# Altium schematic sheet Sheet2.SchDoc(Sheet2)
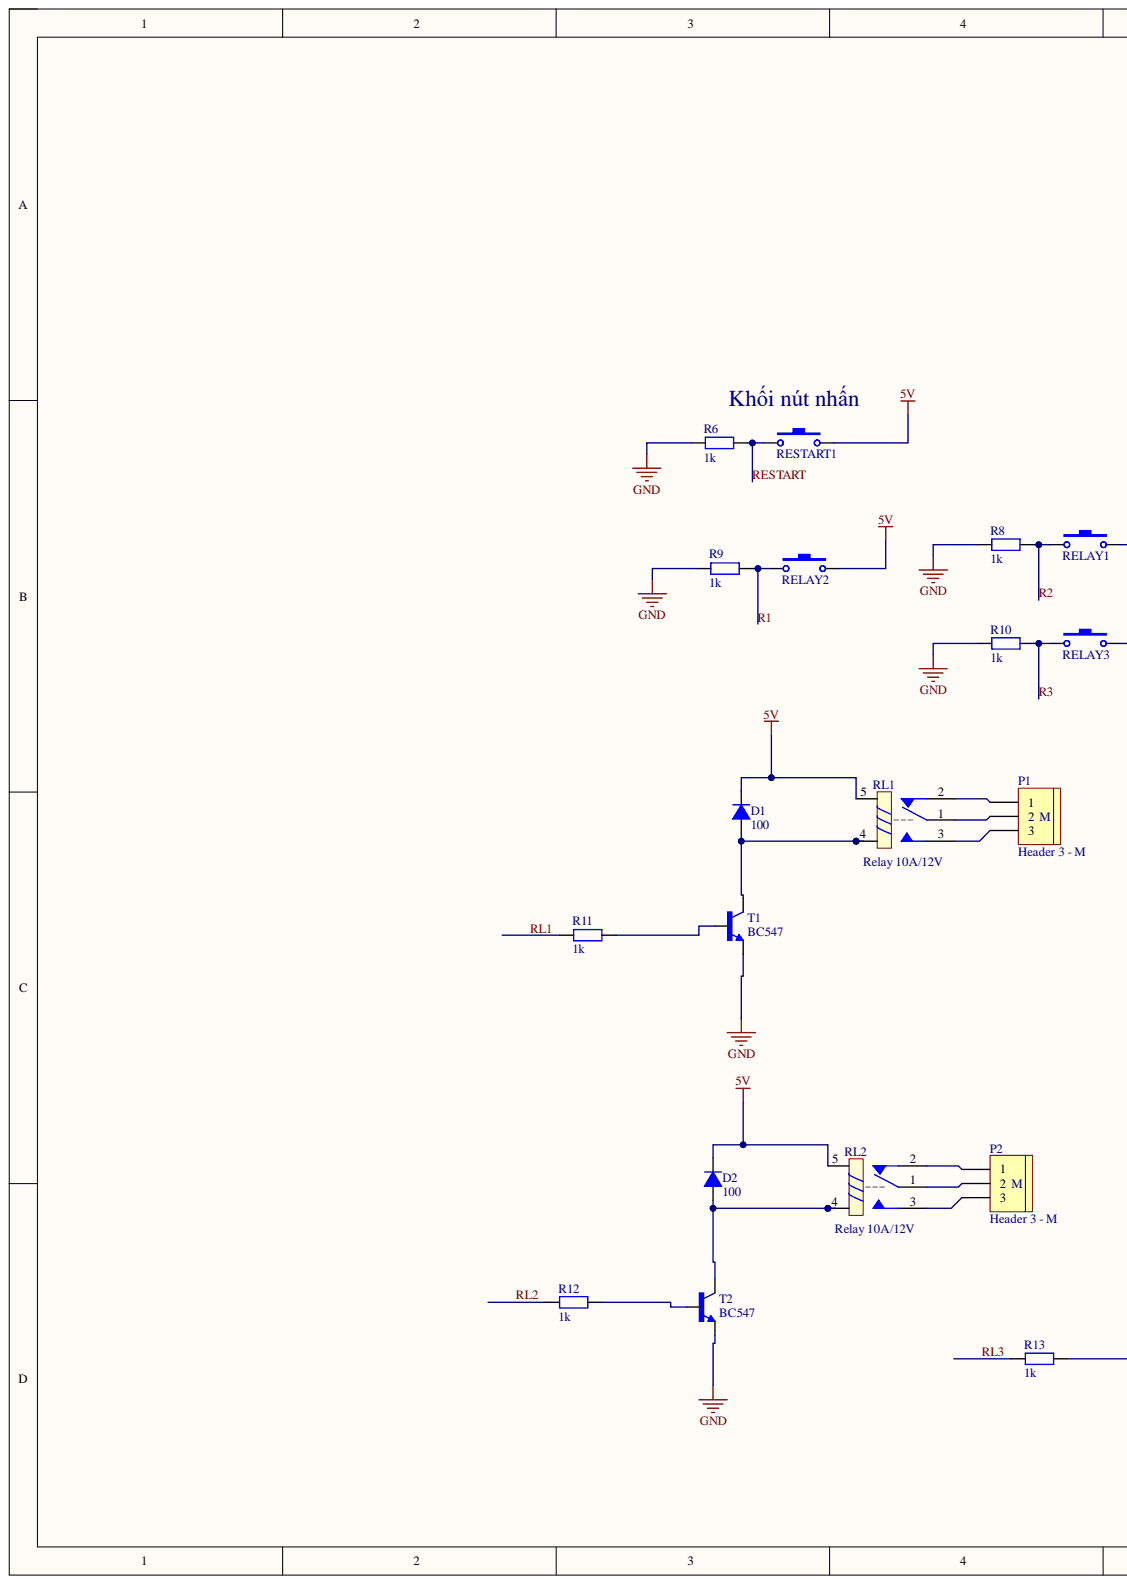
[diagram p0: Sheet2.SchDoc, left]
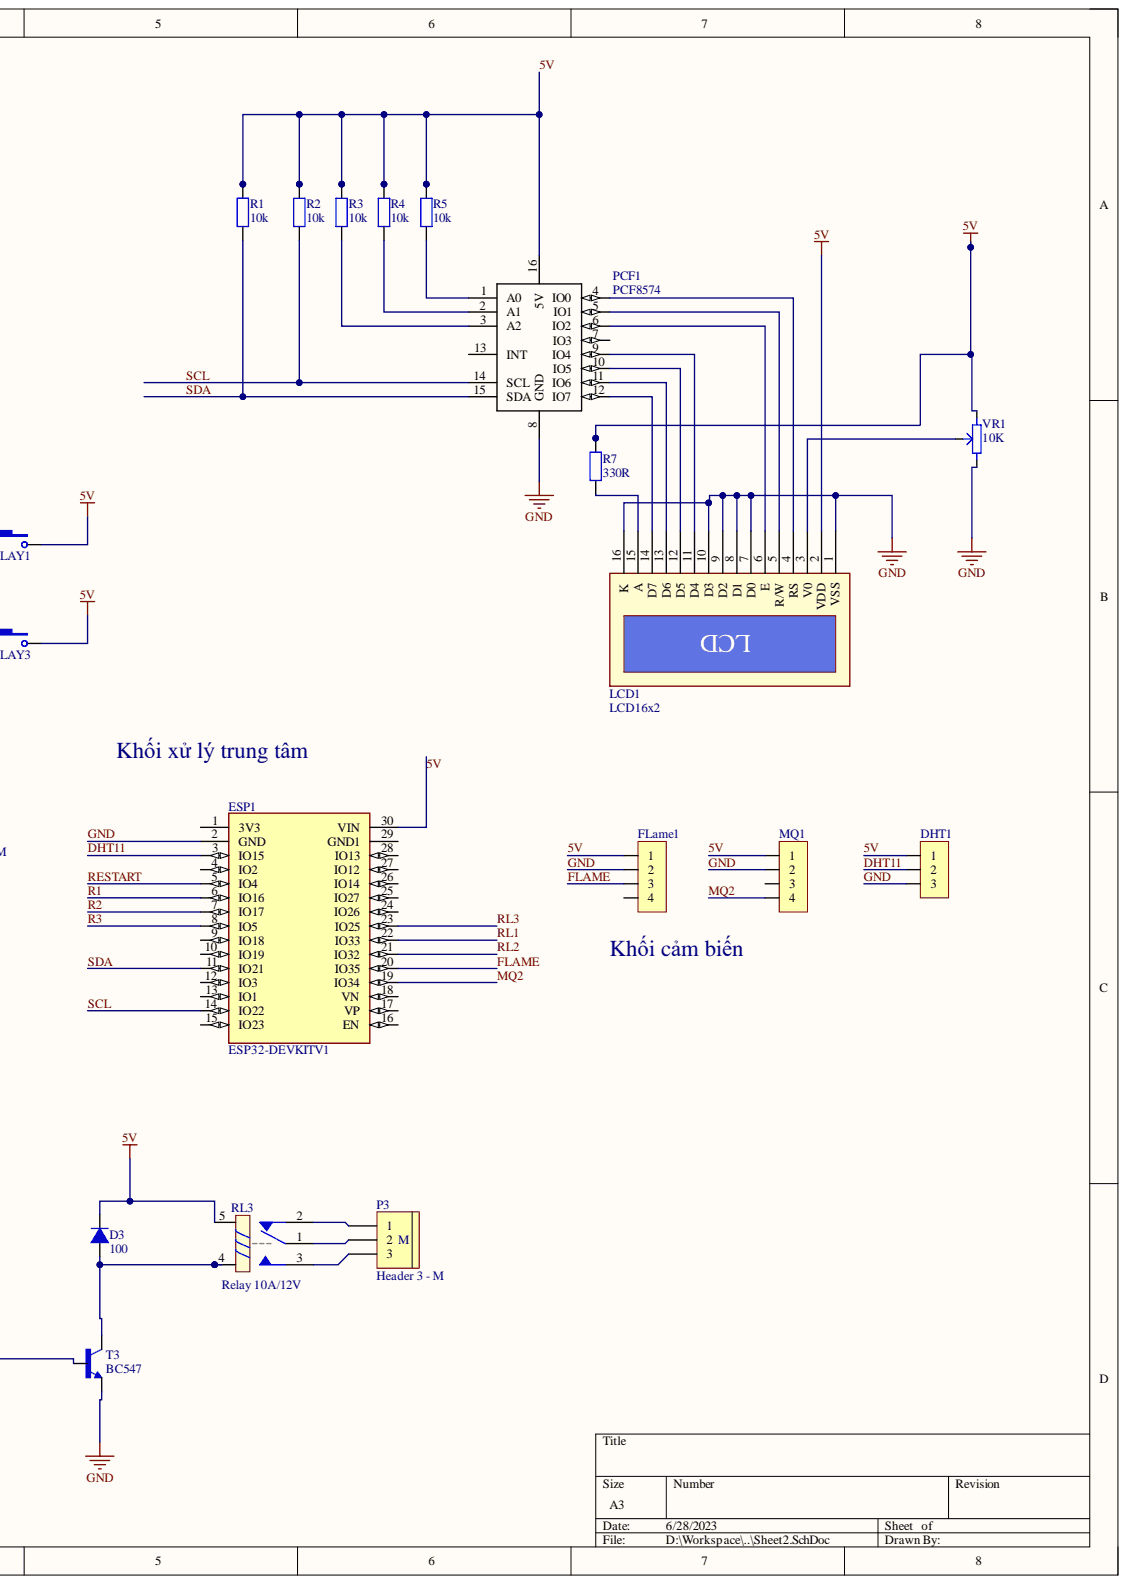
[diagram p1: Sheet2.SchDoc, right]

## Parts
D1  100  [DIODE 1A]
D2  100  [DIODE 1A]
D3  100  [DIODE 1A]
DHT1  JP 1x3 2.54  [JP 1x3 CC]  (value not drawn)
ESP1  ESP32DEVKITV1  [ESP32-DEVKITV1_3D]
FLame1  JP 1x4 2.54  [JP 1x4 CT]  (value not drawn)
LCD1  LCD16x2  [LCD16X2]
MQ1  JP 1x4 2.54  [JP 1x4 CT]  (value not drawn)
P1  Header 3 - M  [Medium - Header 3]
P2  Header 3 - M  [Medium - Header 3]
P3  Header 3 - M  [Medium - Header 3]
PCF1  PCF8574  [DIP16]
R1  10k  [tro smd 0805]
R2  10k  [tro smd 0805]
R3  10k  [tro smd 0805]
R4  10k  [tro smd 0805]
R5  10k  [tro smd 0805]
R6  1k  [RES-1/4W]
R7  330R  [Tro SMD 0805]
R8  1k  [RES-1/4W]
R9  1k  [RES-1/4W]
R10  1k  [RES-1/4W]
R11  1k  [RES-1/4W]
R12  1k  [RES-1/4W]
R13  1k  [RES-1/4W]
RELAY1  SW  [BUTTON  ROUND]  (value not drawn)
RELAY2  SW  [BUTTON  ROUND]  (value not drawn)
RELAY3  SW  [BUTTON  ROUND]  (value not drawn)
RESTART1  SW  [BUTTON  ROUND]  (value not drawn)
RL1  Relay 10A/12V  [RL_SPDT_10A]
RL2  Relay 10A/12V  [RL_SPDT_10A]
RL3  Relay 10A/12V  [RL_SPDT_10A]
T1  BC547  [TO92]
T2  BC547  [TO92]
T3  BC547  [TO92]
VR1  10K  [Bien Tro Tam Giac Dung]

## Nets
5V: D1.2 D2.2 D3.2 DHT1.1 ESP1.30(VIN) FLame1.1 LCD1.2(VDD) MQ1.1 PCF1.16(5V) R1.2 R2.2 R3.2 R4.2 R5.2 R7.2 RELAY1.2 RELAY2.2 RELAY3.2 RESTART1.2 RL1.5 RL2.5 RL3.5 VR1.3
DHT11: DHT1.2 ESP1.3(IO15)
FLAME: ESP1.20(IO35) FLame1.3
GND: DHT1.3 ESP1.2(GND) FLame1.2 LCD1.1(VSS) LCD1.7(D0) LCD1.8(D1) LCD1.9(D2) LCD1.10(D3) LCD1.16(K) MQ1.2 PCF1.8(GND) R6.1 R8.1 R9.1 R10.1 T1.1 T2.1 T3.1 VR1.1
MQ2: ESP1.19(IO34) MQ1.4
R1: ESP1.6(IO16) R9.2 RELAY2.1
R2: ESP1.7(IO17) R8.2 RELAY1.1
R3: ESP1.8(IO5) R10.2 RELAY3.1
RESTART: ESP1.5(IO4) R6.2 RESTART1.1
RL1: ESP1.22(IO33) R11.1
RL2: ESP1.21(IO32) R12.1
RL3: ESP1.23(IO25) R13.1
SCL: ESP1.14(IO22) PCF1.14(SCL) R2.1
SDA: ESP1.11(IO21) PCF1.15(SDA) R1.1
NetD1_1: D1.1 RL1.4 T1.3
NetD2_1: D2.1 RL2.4 T2.3
NetD3_1: D3.1 RL3.4 T3.3
NetLCD1_3: LCD1.3(V0) VR1.2
NetLCD1_4: LCD1.4(RS) PCF1.4(IO0)
NetLCD1_5: LCD1.5(R/W) PCF1.5(IO1)
NetLCD1_6: LCD1.6(E) PCF1.6(IO2)
NetLCD1_11: LCD1.11(D4) PCF1.9(IO4)
NetLCD1_12: LCD1.12(D5) PCF1.10(IO5)
NetLCD1_13: LCD1.13(D6) PCF1.11(IO6)
NetLCD1_14: LCD1.14(D7) PCF1.12(IO7)
NetLCD1_15: LCD1.15(A) R7.1
NetP1_1: P1.1 RL1.2
NetP1_2: P1.2 RL1.1
NetP1_3: P1.3 RL1.3
NetP2_1: P2.1 RL2.2
NetP2_2: P2.2 RL2.1
NetP2_3: P2.3 RL2.3
NetP3_1: P3.1 RL3.2
NetP3_2: P3.2 RL3.1
NetP3_3: P3.3 RL3.3
NetPCF1_1: PCF1.1(A0) R5.1
NetPCF1_2: PCF1.2(A1) R4.1
NetPCF1_3: PCF1.3(A2) R3.1
NetR11_2: R11.2 T1.2
NetR12_2: R12.2 T2.2
NetR13_2: R13.2 T3.2

Not connected: ESP1 1(3V3) 4(IO2) 9(IO18) 10(IO19) 12(IO3) 13(IO1) 15(IO23) 16(EN) 17(VP) 18(VN) 24(IO26) 25(IO27) 26(IO14) 27(IO12) 28(IO13) 29(GND1); FLame1 4; MQ1 3; PCF1 7(IO3) 13(INT)

## Library data of the parts
D1-D3 = Diode 1N4007: Diode 1N4007 cắm; Don_Vi: Con; Ma_Hang: MH001063; Ten_Linh_Kien: Diode 1N4007 1A (DIP); Chung_Loai: Cắm; Component Kind: Standard; Library Name: DIODE.SchLib
DHT1 = JP 1x3 2.54 Cai Cong: Cắm; Don_Vi: Con; Ma_Hang: MH001265; Ten_Linh_Kien: Jump Đơn Cái 2.54MM 1x40P Cong; Chung_Loai: Cắm; Component Kind: Standard; Library Name: Jump.SchLib
ESP1 = ESP32DEVKITV1: Dual core, Wi-Fi: 2.4 GHz up to 150 Mbits/s,BLE (Bluetooth Low Energy) and legacy Bluetooth, 32 bits, Up to 240 MHz; MF: Do it; Availability: Unavailable; Check_prices: https://www.snapeda.com/parts/ESP32%20DEVKIT%20V1/Do%20it/view-part/5659245/?ref=eda; Component Kind: Standard; MP: ESP32 DEVKIT V1; Package: Package; Price: None; SnapEDA_Link: https://www.snapeda.com/parts/ESP32%20DEVKIT%20V1/Do%20it/view-part/5659245/?ref=snap; Library Name: SchlibBruno.SchLib
FLame1 MQ1 = JP 1x4 2.54 Cai Thang: Cắm; Don_Vi: Con; Ma_Hang: MH004006; Ten_Linh_Kien: Jump Đơn Cái 2.54MM 1x20P Thẳng; Chung_Loai: Cắm; Component Kind: Standard; Library Name: Jump.SchLib
LCD1 = LCD Text: jump hàn; Component Kind: Standard; Library Name: KhanhBK_SCH_Library_2.SchLib
P1-P3 = Header 3 - M: Header, 3-Pin, Medium; Component Kind: Standard; LatestRevisionDate: 17-Jul-2002; LatestRevisionNote: Re-released for DXP Platform.; Signal Integrity: Connector; Library Name: KhanhBK_SCH_Library_2.SchLib; Publisher: Altium Limited
PCF1 = PCF8574: I2C PORT EXPANDER dán; Manufacturer: NXP Semiconductors; Manufacturer Part Number: PCF8574T/3,512; Frequency - Clock: 100KHz; Includes: POR; Interface: I²C; Interrupt Output: Yes; Number of I /O: 8; Voltage - Supply: 2.5 V ~ 6 V; Category: Integrated Circuits (ICs); Component Kind: Standard; Operating Temperature: -40°C ~ 85°C; Package / Case: 16-SOIC (7.5mm Width); Packaging: Tube; RoHS: RoHS Compliant; Supplier: Digi-Key; Supplier 1: Digi-Key; Supplier Part Number: 568-1076-5-ND; Supplier Part Number 1: 568-1076-5-ND; Library Name: KhanhBK_SCH_Library_2.SchLib
R1-R3 R5 = Tro 0805 1% 10k: Dán; Don_Vi: Con; Ma_Hang: MH005473; So_Chan: 2; Ten_Linh_Kien: Trở 0805 1% 10k; Chung_Loai: Dán; Component Kind: Standard; Library Name: Dien Tro.SchLib
R4 = Tro 0805 5% 10k: Dán; Don_Vi: Con; Ma_Hang: MH005239; So_Chan: 2; Ten_Linh_Kien: Trở 0805 5% 10k; Chung_Loai: Dán; Component Kind: Standard; Library Name: Dien Tro.SchLib
R6 R8-R13 = R: Resistor R1/4W; Component Kind: Standard; Library Name: Resistor.SchLib
R7 = Tro 0805 5% 330R: Dán; Don_Vi: Con; Ma_Hang: MH005337; So_Chan: 2; Ten_Linh_Kien: Trở 0805 5% 330R; Chung_Loai: Dán; Component Kind: Standard; Library Name: Dien Tro.SchLib
RELAY1-RELAY3 RESTART1 = SW-Button: Switch-Button; Component Kind: Standard; LatestRevisionDate: 17-Jul-2002; LatestRevisionNote: Re-released for DXP Platform.; Library Name: BUTTON-SWITCH.SchLib; PackageReference: SPST-2; Published: 24-Mar-1999; Publisher: Altium Limited
RL1-RL3 = Relay 1: Relay SPDT,10A - 12VDC 5 Pin; Value: Relay SPDT, 10A, 12V; Component Kind: Standard; Library Name: RELAY.SchLib
T1-T3 = BC547: Bipolar (BJT) Transistor NPN 45V 100mA 300MHz 625mW Through Hole TO-92 (TO-226); MF: ON; Availability: In Stock; Check_prices: https://www.snapeda.com/parts/BC547/Onsemi/view-part/?ref=eda; Component Kind: Standard; Library Name: BC547.IntLib; MP: BC547; Package: E-PKG AXIAL-LEADED-2 ON Semiconductor; Price: None; Purchase-URL: https://pricing.snapeda.com/search/part/BC547/?ref=eda; SnapEDA_Link: https://www.snapeda.com/parts/BC547/Onsemi/view-part/?ref=snap
VR1 = Bien tro tam giac 10K Dung: Cắm; Don_Vi: Con; Ma_Hang: MH000919; Ten_Linh_Kien: Biến Trở Tam Giác 103-10K RM065 (Chân Đứng); Chung_Loai: Cắm; Component Kind: Standard; Library Name: Dien Tro.SchLib
